# Altium schematic sheet STM32_MCU.SchDoc(STM32_MCU)
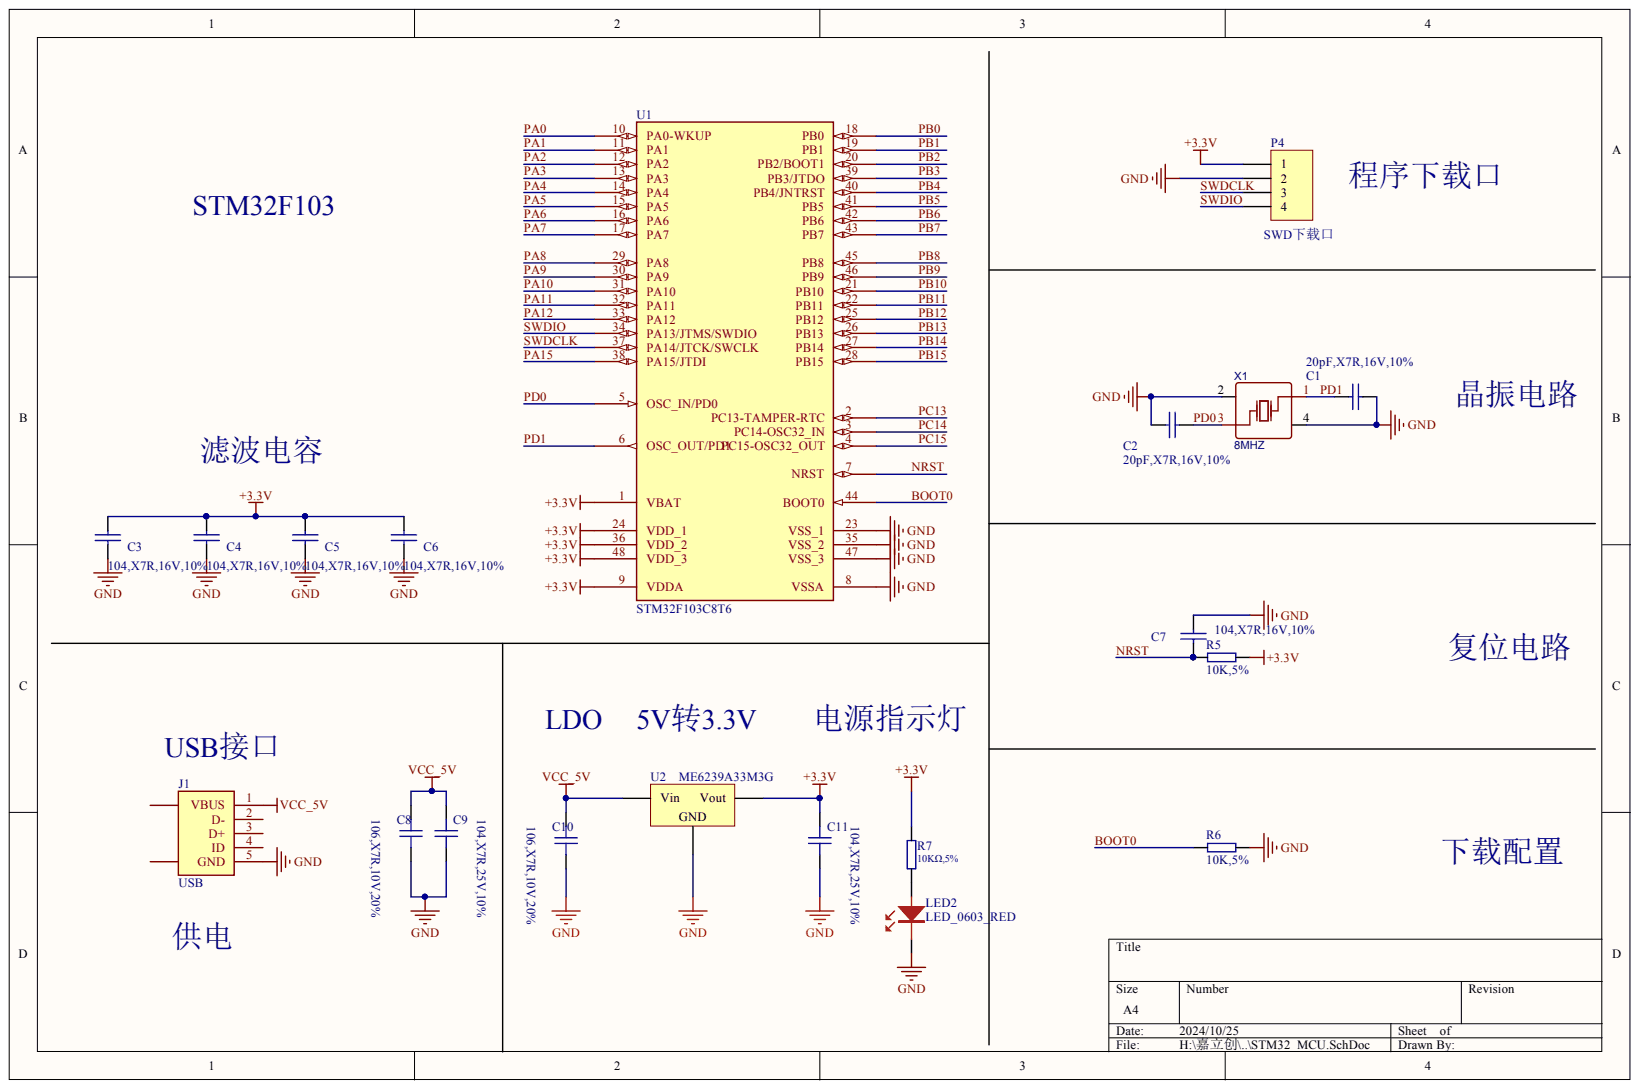
[diagram p0: STM32_MCU.SchDoc]

## Parts
C1  20pF,X7R,16V,10%  [SMD]
C2  20pF,X7R,16V,10%  [SMD]
C3  104,X7R,16V,10%  [SMD]
C4  104,X7R,16V,10%  [SMD]
C5  104,X7R,16V,10%  [SMD]
C6  104,X7R,16V,10%  [SMD]
C7  104,X7R,16V,10%  [SMD]
C8  106,X7R,10V,20%  [CAP_0603]
C9  104,X7R,25V,10%  [CAP_0603]
C10  106,X7R,10V,20%  [CAP_0603]
C11  104,X7R,25V,10%  [CAP_0603]
J1  USB  [USB-Mirco-5SB]
LED2  LED_0603_RED  [LED-0603]
P4  SWD下载口  [HDR1X4]
R5  10K,5%  [SMD]
R6  10K,5%  [SMD]
R7  10KΩ,5%  [SMD]
U1  STM32F103C8T6  [LQFP-48]
U2  ME6239A33M3G  [SOT23-3]
X1  8MHZ  [CRYSTAL-SMD_4P-L3.2-W2.5-BL-1]

## Nets
+3.3V: C3.1 C4.1 C5.1 C6.1 C11.2 P4.1 R5.2 R7.2 U1.1(VBAT) U1.9(VDDA) U1.24(VDD_1) U1.36(VDD_2) U1.48(VDD_3) U2.2
GND: C1.2 C2.1 C3.2 C4.2 C5.2 C6.2 C7.2 C8.1 C9.1 C10.1 C11.1 J1.5(GND) LED2.2 P4.2 R6.2 U1.8(VSSA) U1.23(VSS_1) U1.35(VSS_2) U1.47(VSS_3) U2.1 X1.2 X1.4
VCC_5V: C8.2 C9.2 C10.2 J1.1(VBUS) U2.3
BOOT0: R6.1 U1.44(BOOT0)
NRST: C7.1 R5.1 U1.7(NRST)
PA0: U1.10(PA0-WKUP)
PA1: U1.11(PA1)
PA2: U1.12(PA2)
PA3: U1.13(PA3)
PA4: U1.14(PA4)
PA5: U1.15(PA5)
PA6: U1.16(PA6)
PA7: U1.17(PA7)
PA8: U1.29(PA8)
PA9: U1.30(PA9)
PA10: U1.31(PA10)
PA11: U1.32(PA11)
PA12: U1.33(PA12)
PA15: U1.38(PA15/JTDI)
PB0: U1.18(PB0)
PB1: U1.19(PB1)
PB2: U1.20(PB2/BOOT1)
PB3: U1.39(PB3/JTDO)
PB4: U1.40(PB4/JNTRST)
PB5: U1.41(PB5)
PB6: U1.42(PB6)
PB7: U1.43(PB7)
PB8: U1.45(PB8)
PB9: U1.46(PB9)
PB10: U1.21(PB10)
PB11: U1.22(PB11)
PB12: U1.25(PB12)
PB13: U1.26(PB13)
PB14: U1.27(PB14)
PB15: U1.28(PB15)
PC13: U1.2(PC13-TAMPER-RTC)
PC14: U1.3(PC14-OSC32_IN)
PC15: U1.4(PC15-OSC32_OUT)
PD0: C2.2 U1.5(OSC_IN/PD0) X1.3
PD1: C1.1 U1.6(OSC_OUT/PD1) X1.1
SWDCLK: P4.3 U1.37(PA14/JTCK/SWCLK)
SWDIO: P4.4 U1.34(PA13/JTMS/SWDIO)
NetLED2_1: LED2.1 R7.1

Not connected: J1 0 2(D-) 3(D+) 4(ID)

## Library data of the parts
C1 C2 = Pro2B.tmp_C104_18: 无极电容,NPO,±0.25pF,耐压16-25v; Electronic Manufacturer: SAMSUNG; Clearance: A; IC_ULT: NULL; IC Types: 贴片电容; Component Kind: Standard; Unit Price: 0.05
C3-C7 = Pro2B.tmp_C104_18: 无极电容,NPO,±10%,耐压16-25v; Electronic Manufacturer: SAMSUNG; Clearance: A; IC_ULT: NULL; IC Types: 贴片电容; Component Kind: Standard; Unit Price: 0.05
C8-C11 = CAP: Component Kind: Standard; Library Name: 我的元件库.IntLib
LED2 = LED_R: Component Kind: Standard
P4 = Header 4: Header, 4-Pin; Component Kind: Standard; LatestRevisionDate: 17-Jul-2002; LatestRevisionNote: Re-released for DXP Platform.; Library Name: Miscellaneous Connectors.IntLib; Signal Integrity: Connector; Publisher: Altium Limited
R5 R6 = DIAN_ZHU: Electronic Manufacturer: NULL; Clearance: A; Component Kind: Standard; IC_ULT: NULL; IC Types: NULL; Unit Price: NULL
R7 = Pro1B.tmp_R150_46: 贴片电阻，±5%; Electronic Manufacturer: YAGEO; Clearance: A; IC_ULT: NULL; IC Types: 贴片电阻; Component Kind: Standard; Unit Price: 0.03
U1 = STM32F103C8T6_1: STM32单片机; Component Kind: Standard; Library Name: SRIE_SCH.SchLib
U2 = Volt Reg: Voltage Regulator; Component Kind: Standard; LatestRevisionDate: 29-May-2009; LatestRevisionNote: IPC-7351 Footprint Added.; Library Name: Miscellaneous Devices.IntLib; PackageDescription: 3-Pin Transistor Outline Package 2.54 mm Pitch, 15.1 mm Lead Span; PackageReference: D2PAK; Published: 24-Mar-1999; Publisher: Altium Limited
X1 = -: BOM_Manufacturer: TAITIEN Elec; BOM_Manufacturer Part: XXDBBCNANF-27.12M; Contributor: LCSC; nameAlias: Frequency; BOM_JLCPCB Part Class: Extended Part; BOM_Supplier: LCSC; BOM_Supplier Part: C124317; Component Kind: Standard; spicePre: X; spiceSymbolName: XXDBBCNANF-27.12M
J1 = USB: Component Kind: Standard; Library Name: 我的元件.lib
